# Altium schematic sheet P0_Main_Connect.SchDoc
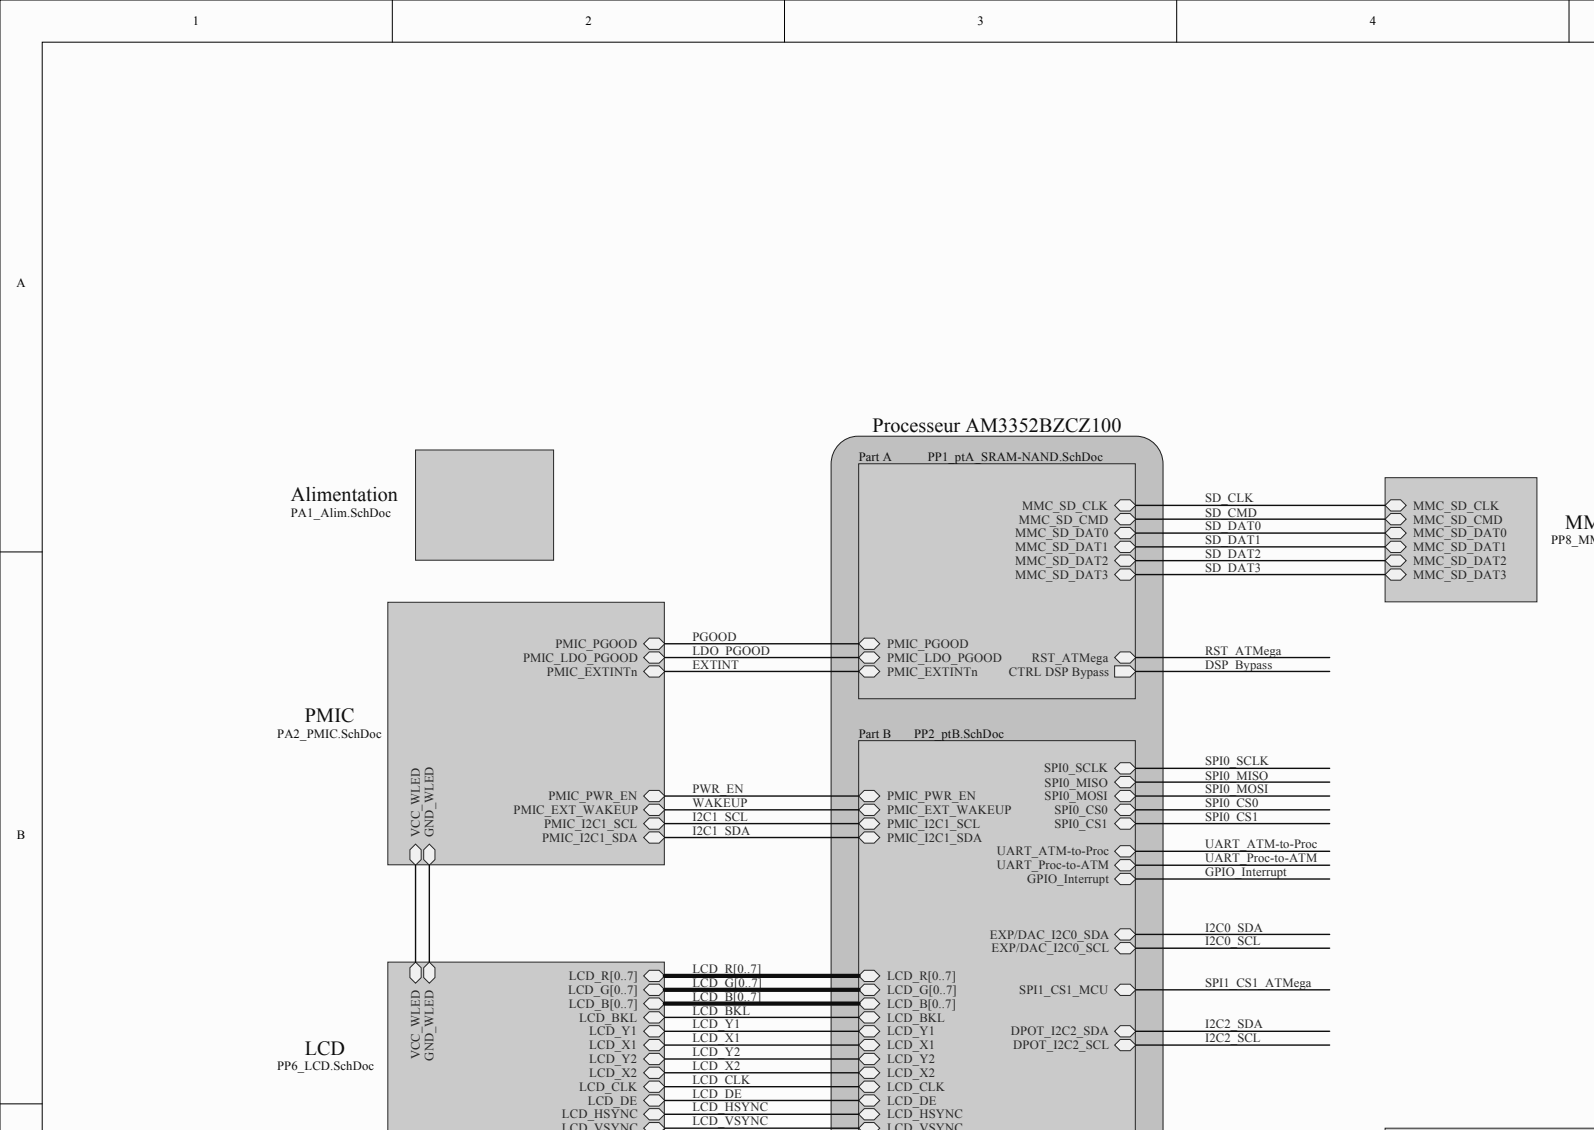
[diagram p0: P0_Main_Connect.SchDoc, top left]
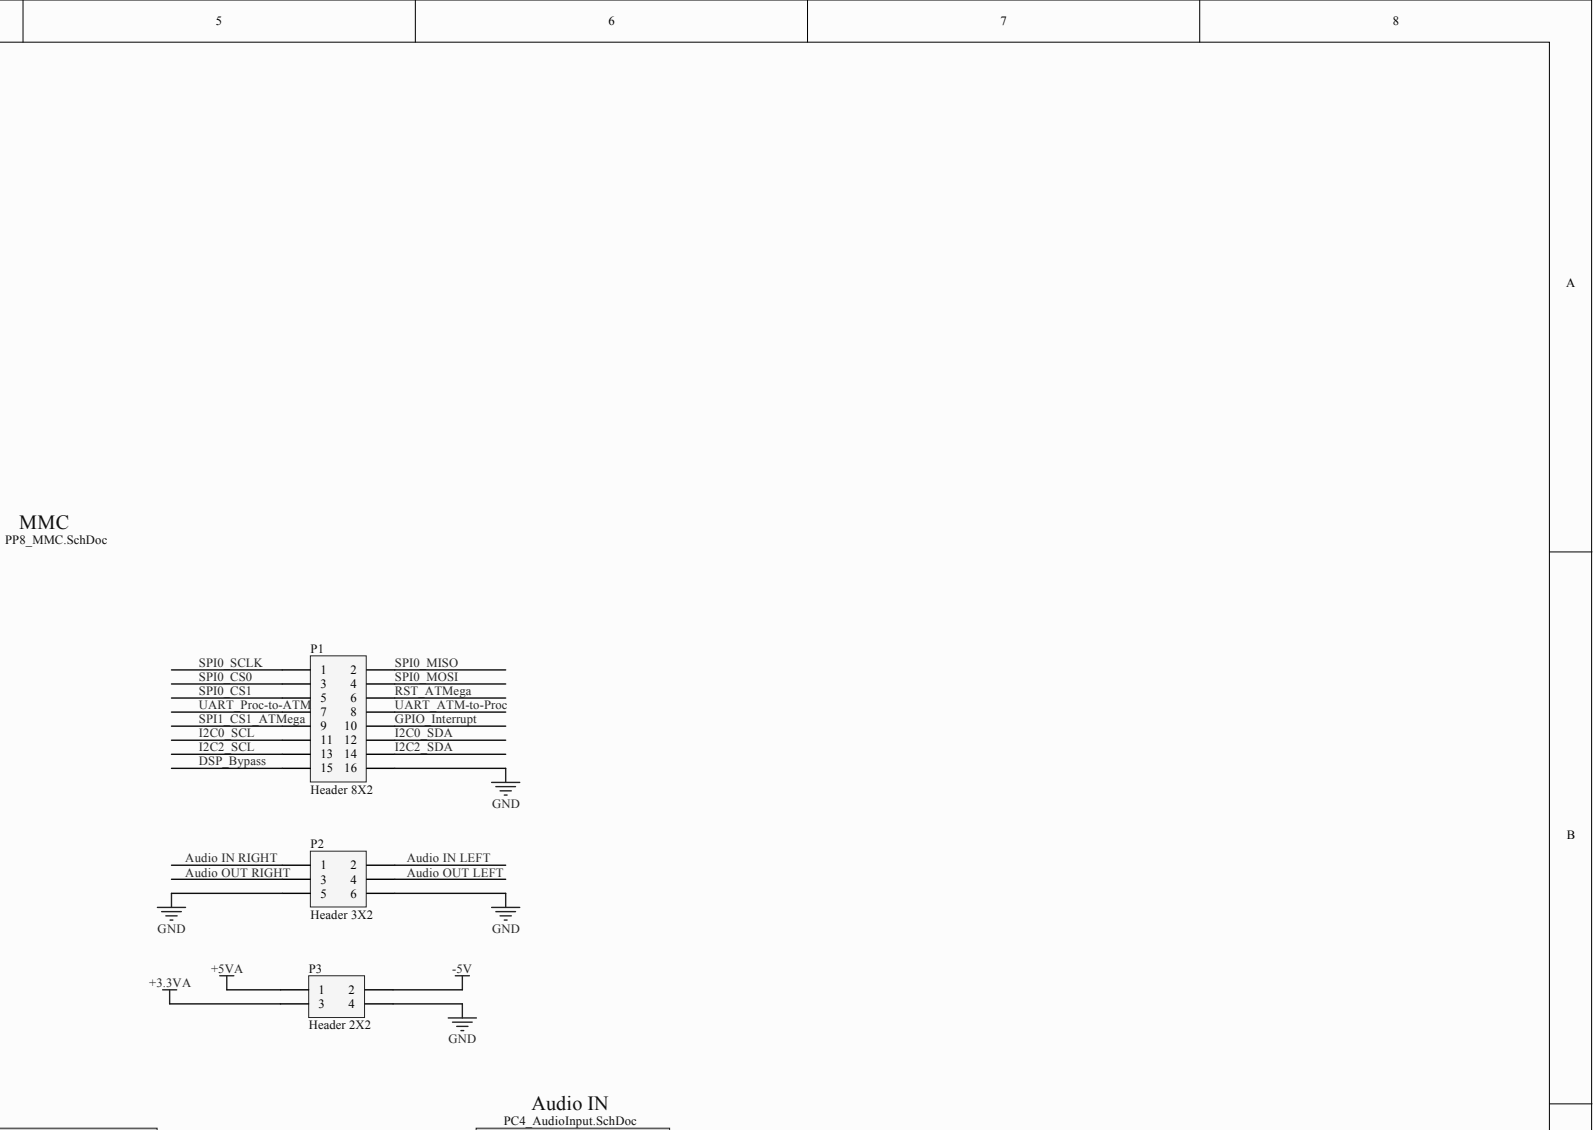
[diagram p1: P0_Main_Connect.SchDoc, top right]
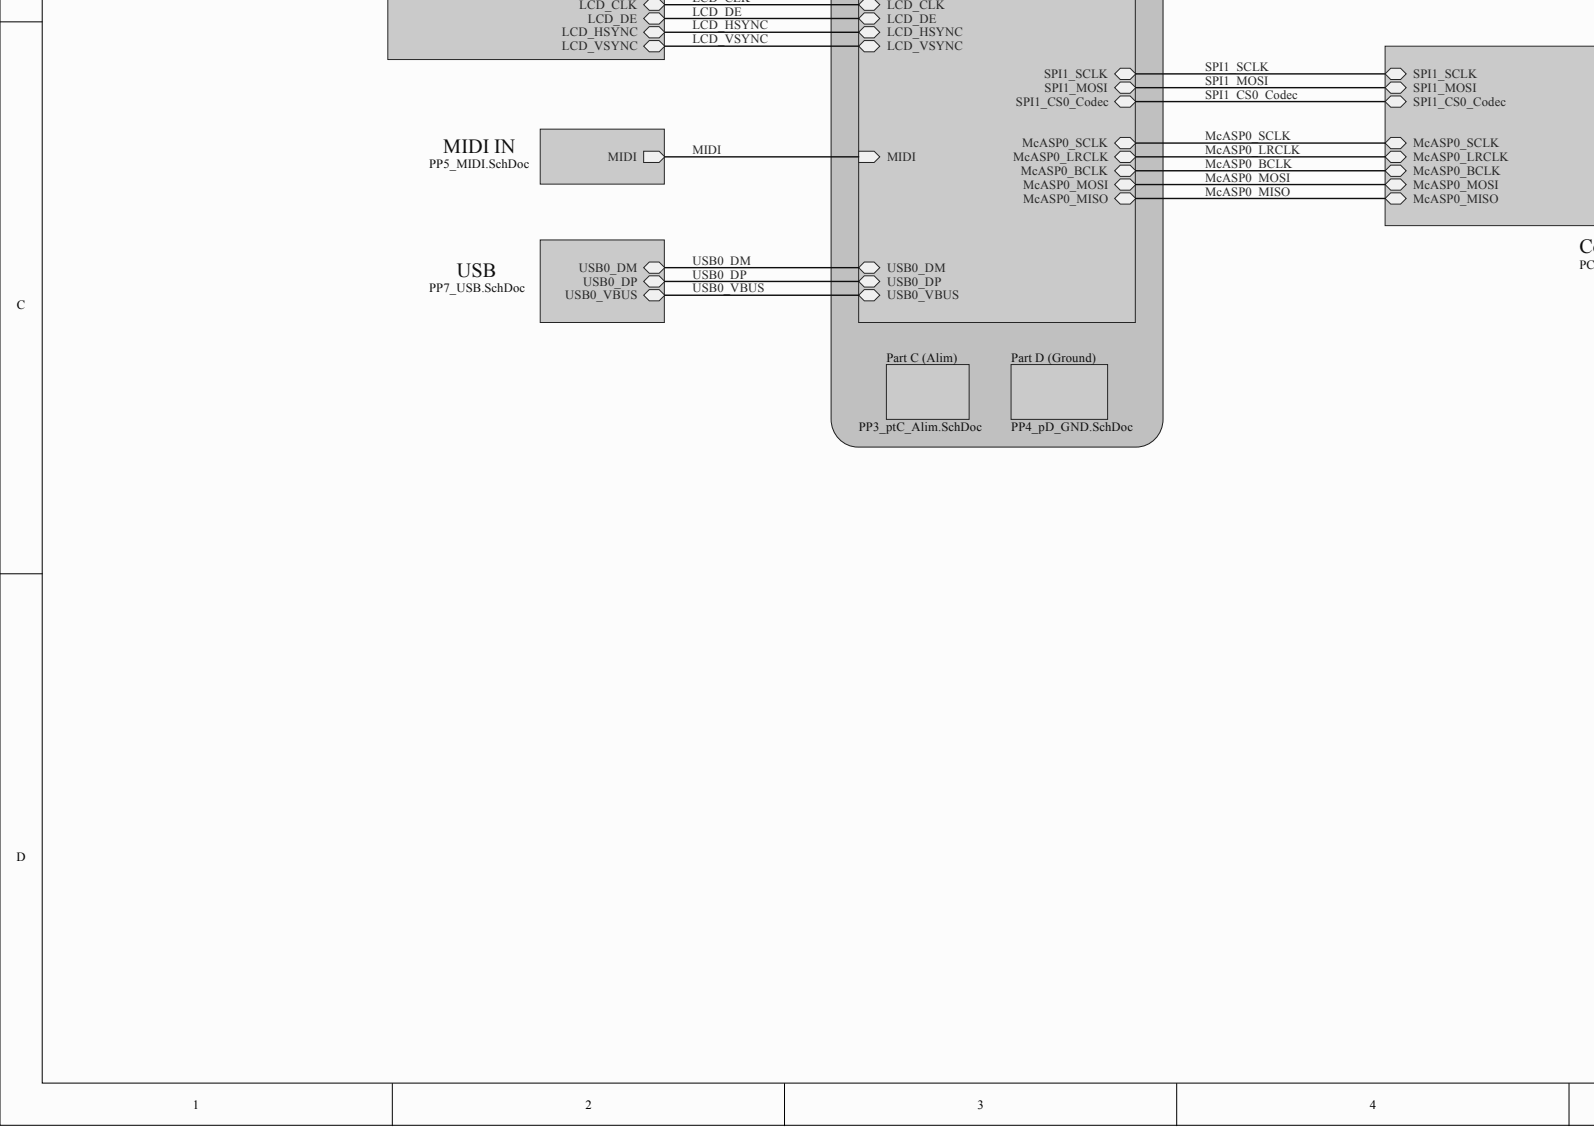
[diagram p2: P0_Main_Connect.SchDoc, bottom left]
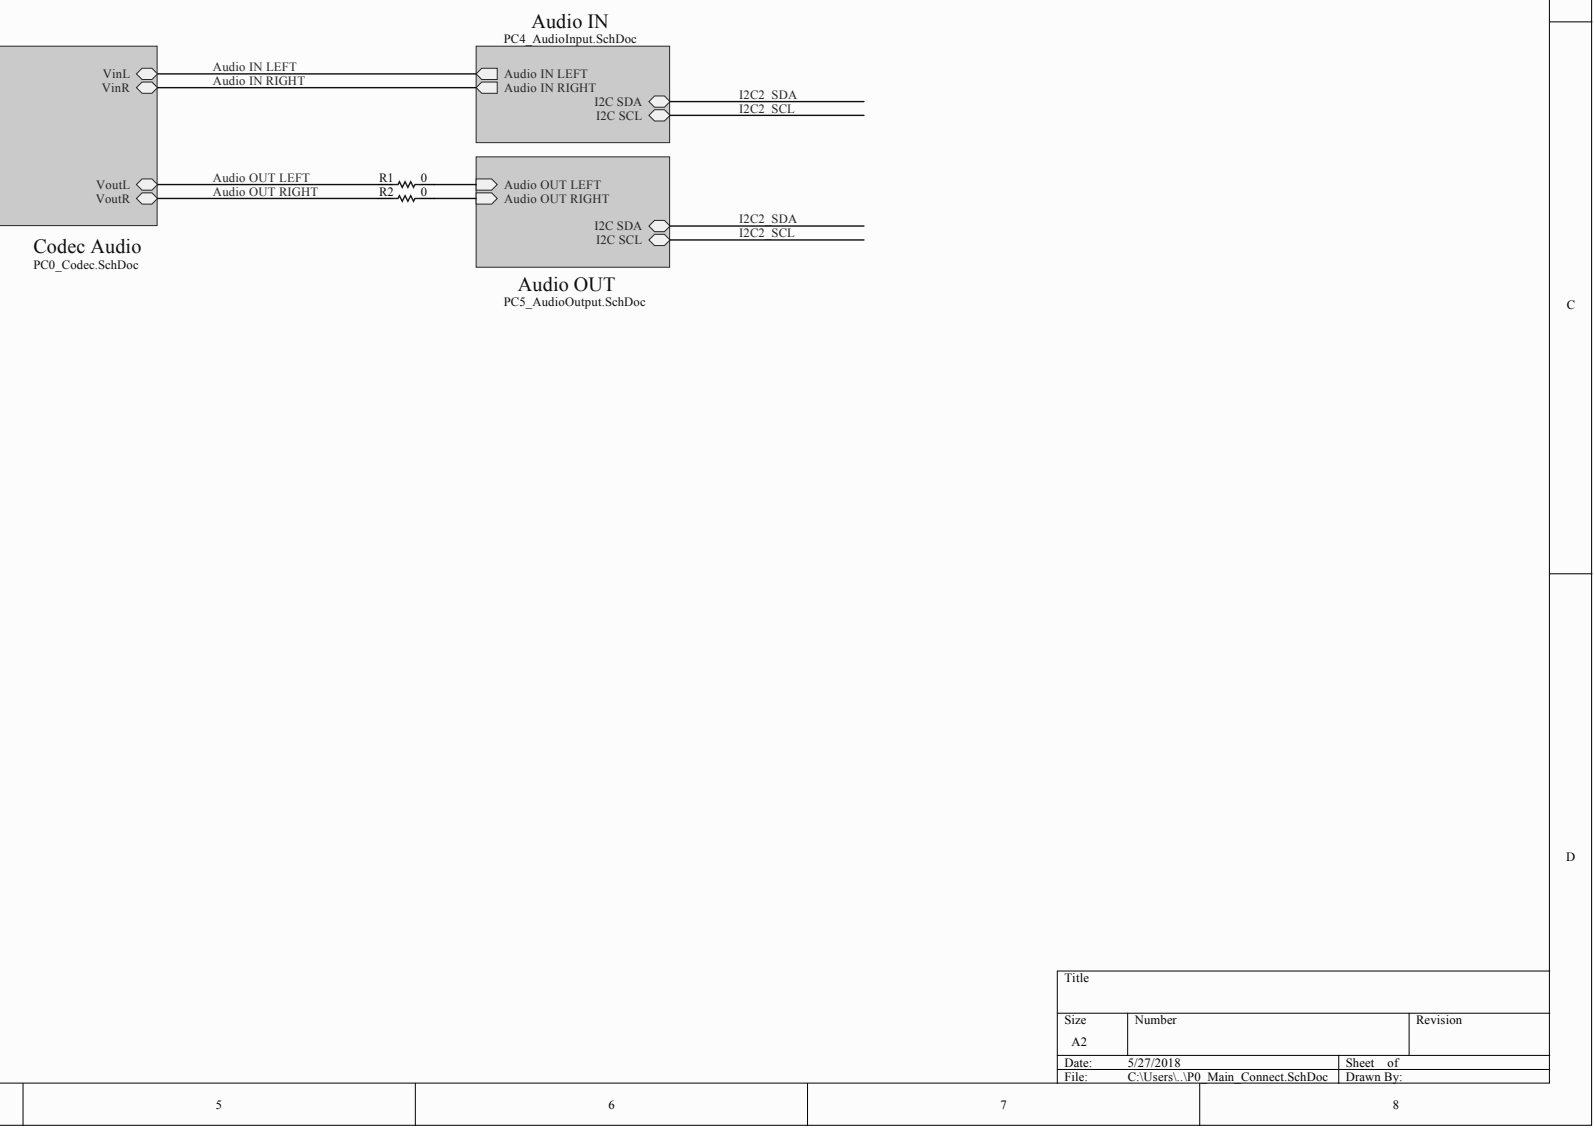
[diagram p3: P0_Main_Connect.SchDoc, bottom right]

## Parts
P1  Header 8X2  [CONN_1241050-8_T]
P2  Header 3X2  [CONN_1241050-3_T]
P3  Header 2X2  [CONN_1241050-2_T]
R1  value 0  [RESC1608X05]  (hidden comment: Res 0 Ohm)
R2  value 0  [RESC1608X05]  (hidden comment: Res 0 Ohm)

## Nets
+3.3VA: P3.3
+5VA: P3.1
-5V: P3.2
GND: P1.16 P2.5 P2.6 P3.4
AUDIO IN LEFT: P2.2
AUDIO IN RIGHT: P2.1
AUDIO OUT LEFT: P2.4 R1.1
AUDIO OUT RIGHT: P2.3 R2.1
DSP_BYPASS: P1.15
GPIO_INTERRUPT: P1.10
I2C0_SCL: P1.11
I2C0_SDA: P1.12
I2C2_SCL: P1.13
I2C2_SDA: P1.14
RST_ATMEGA: P1.6
SPI0_CS0: P1.3
SPI0_CS1: P1.5
SPI0_MISO: P1.2
SPI0_MOSI: P1.4
SPI0_SCLK: P1.1
SPI1_CS1_ATMEGA: P1.9
UART_ATM-TO-PROC: P1.8
UART_PROC-TO-ATM: P1.7
NetR1_2: R1.2
NetR2_2: R2.2
EXTINT:
GND_WLED:
I2C1_SCL:
I2C1_SDA:
LCD_B0:  [also labelled LCD_B]
LCD_B1:  [also labelled LCD_B]
LCD_B2:  [also labelled LCD_B]
LCD_B3:  [also labelled LCD_B]
LCD_B4:  [also labelled LCD_B]
LCD_B5:  [also labelled LCD_B]
LCD_B6:  [also labelled LCD_B]
LCD_B7:  [also labelled LCD_B]
LCD_BKL:
LCD_CLK:
LCD_DE:
LCD_G0:  [also labelled LCD_G]
LCD_G1:  [also labelled LCD_G]
LCD_G2:  [also labelled LCD_G]
LCD_G3:  [also labelled LCD_G]
LCD_G4:  [also labelled LCD_G]
LCD_G5:  [also labelled LCD_G]
LCD_G6:  [also labelled LCD_G]
LCD_G7:  [also labelled LCD_G]
LCD_HSYNC:
LCD_R0:  [also labelled LCD_R]
LCD_R1:  [also labelled LCD_R]
LCD_R2:  [also labelled LCD_R]
LCD_R3:  [also labelled LCD_R]
LCD_R4:  [also labelled LCD_R]
LCD_R5:  [also labelled LCD_R]
LCD_R6:  [also labelled LCD_R]
LCD_R7:  [also labelled LCD_R]
LCD_VSYNC:
LCD_X1:
LCD_X2:
LCD_Y1:
LCD_Y2:
LDO_PGOOD:
MCASP0_BCLK:
MCASP0_LRCLK:
MCASP0_MISO:
MCASP0_MOSI:
MCASP0_SCLK:
MIDI:
PGOOD:
PWR_EN:
SD_CLK:
SD_CMD:
SD_DAT0:
SD_DAT1:
SD_DAT2:
SD_DAT3:
SPI1_CS0_CODEC:
SPI1_MOSI:
SPI1_SCLK:
USB0_DM:
USB0_DP:
USB0_VBUS:
VCC_WLED:
WAKEUP:

## Library data of the parts
P1 = Header 8X2: Header, 8-Pin, Dual row; Fabricant: SAMTEC; Fournisseur: Farnell; RefFabricant: TSM-108-02-T-DV; RefFournisseur: 2856657; Component Kind: Standard; DataSheet: http://www.farnell.com/datasheets/318453.pdf; Signal Integrity: Connector; Library Name: Michel.SchLib
P2 = Header 3X2: Header, 3-Pin, Dual row; Fabricant: SAMTEC; Fournisseur: Farnell; RefFabricant: TSM-103-01-T-DV; RefFournisseur: 2505049; Component Kind: Standard; DataSheet: http://www.farnell.com/datasheets/318453.pdf; Signal Integrity: Connector; Library Name: Michel.SchLib
P3 = Header 2X2: Header, 2-Pin, Dual row; Fabricant: AMP - TE CONNECTIVITY; Fournisseur: Farnell; RefFabricant: 1241050-2; RefFournisseur: 9689559; Component Kind: Standard; DataSheet: http://www.farnell.com/datasheets/318453.pdf; Signal Integrity: Connector; Library Name: Michel.SchLib
R1 R2 = Res1: Resistor; Fabricant: MULTICOMP; Fournisseur: Farnell; RefFabricant: MCMR06X000 PTL; RefFournisseur: 2073345; Case: 0603 [1608 Metric]; Component Kind: Standard; DataSheet: http://www.farnell.com/datasheets/2549522.pdf; Signal Integrity: Res; Simulation: RESISTOR; Library Name: Michel.SchLib
